# Altium schematic sheet IMX7S_WIRELESS.SchDoc
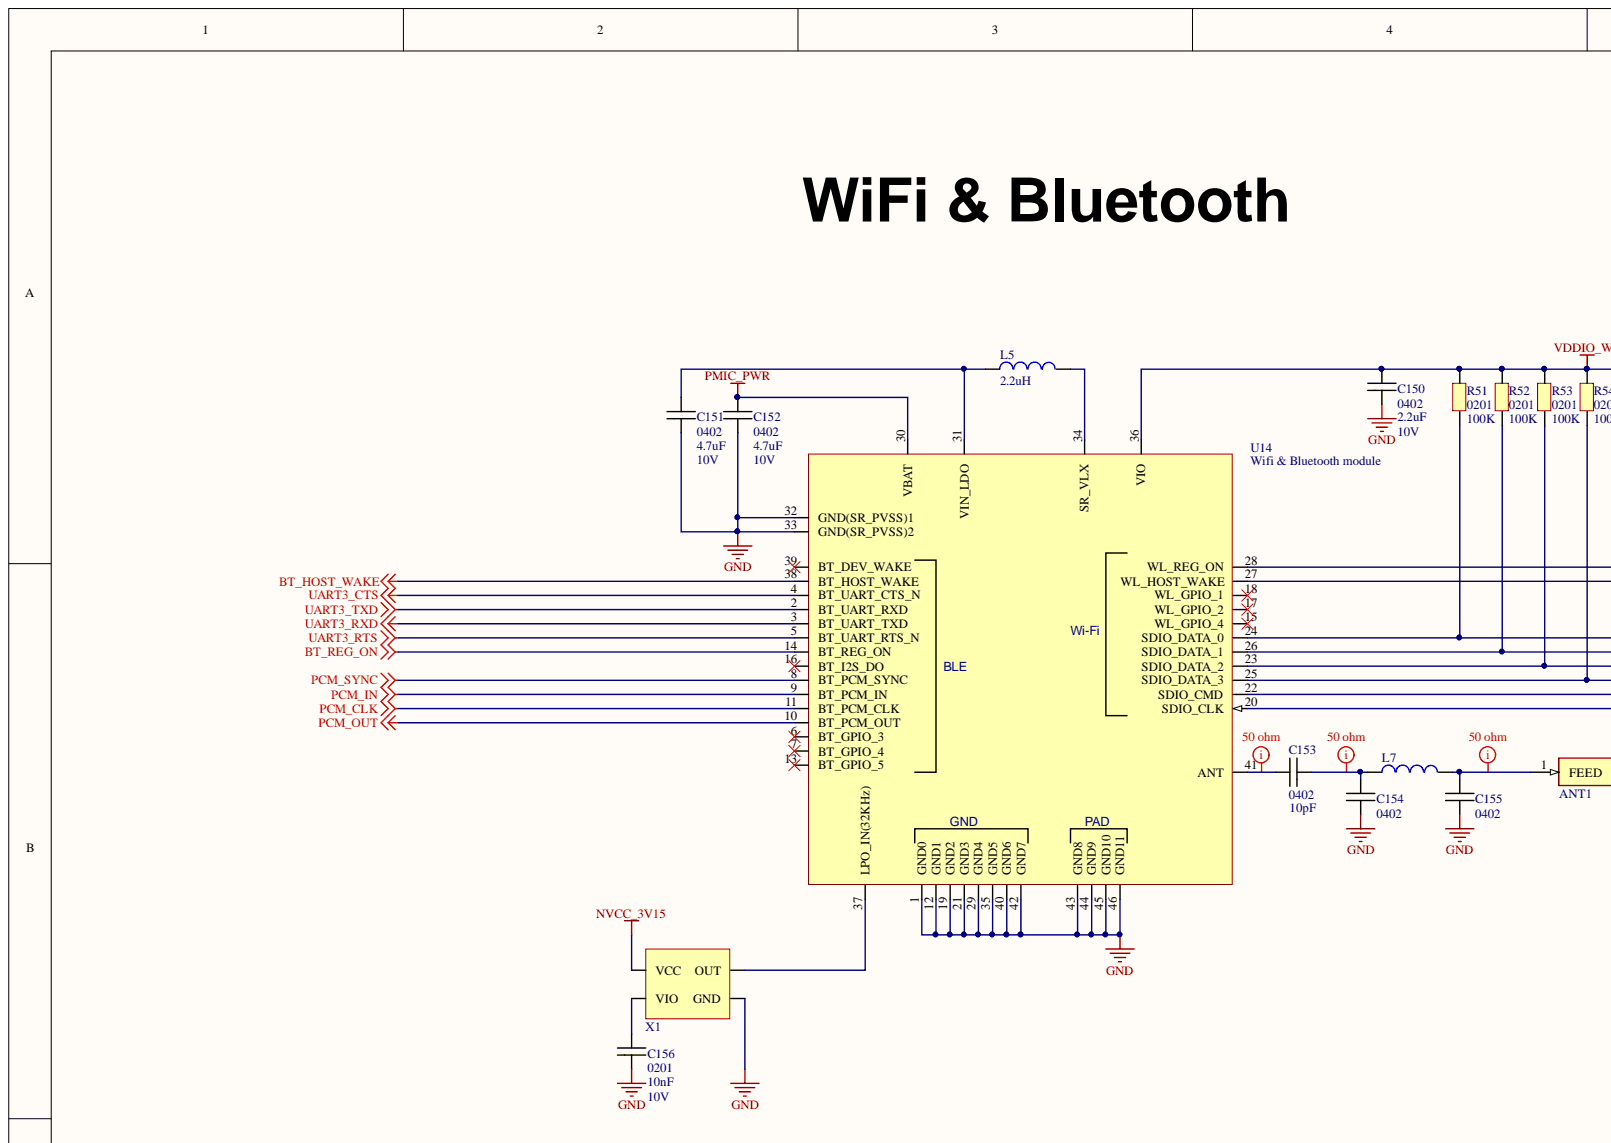
[diagram p0: IMX7S_WIRELESS.SchDoc, top left]
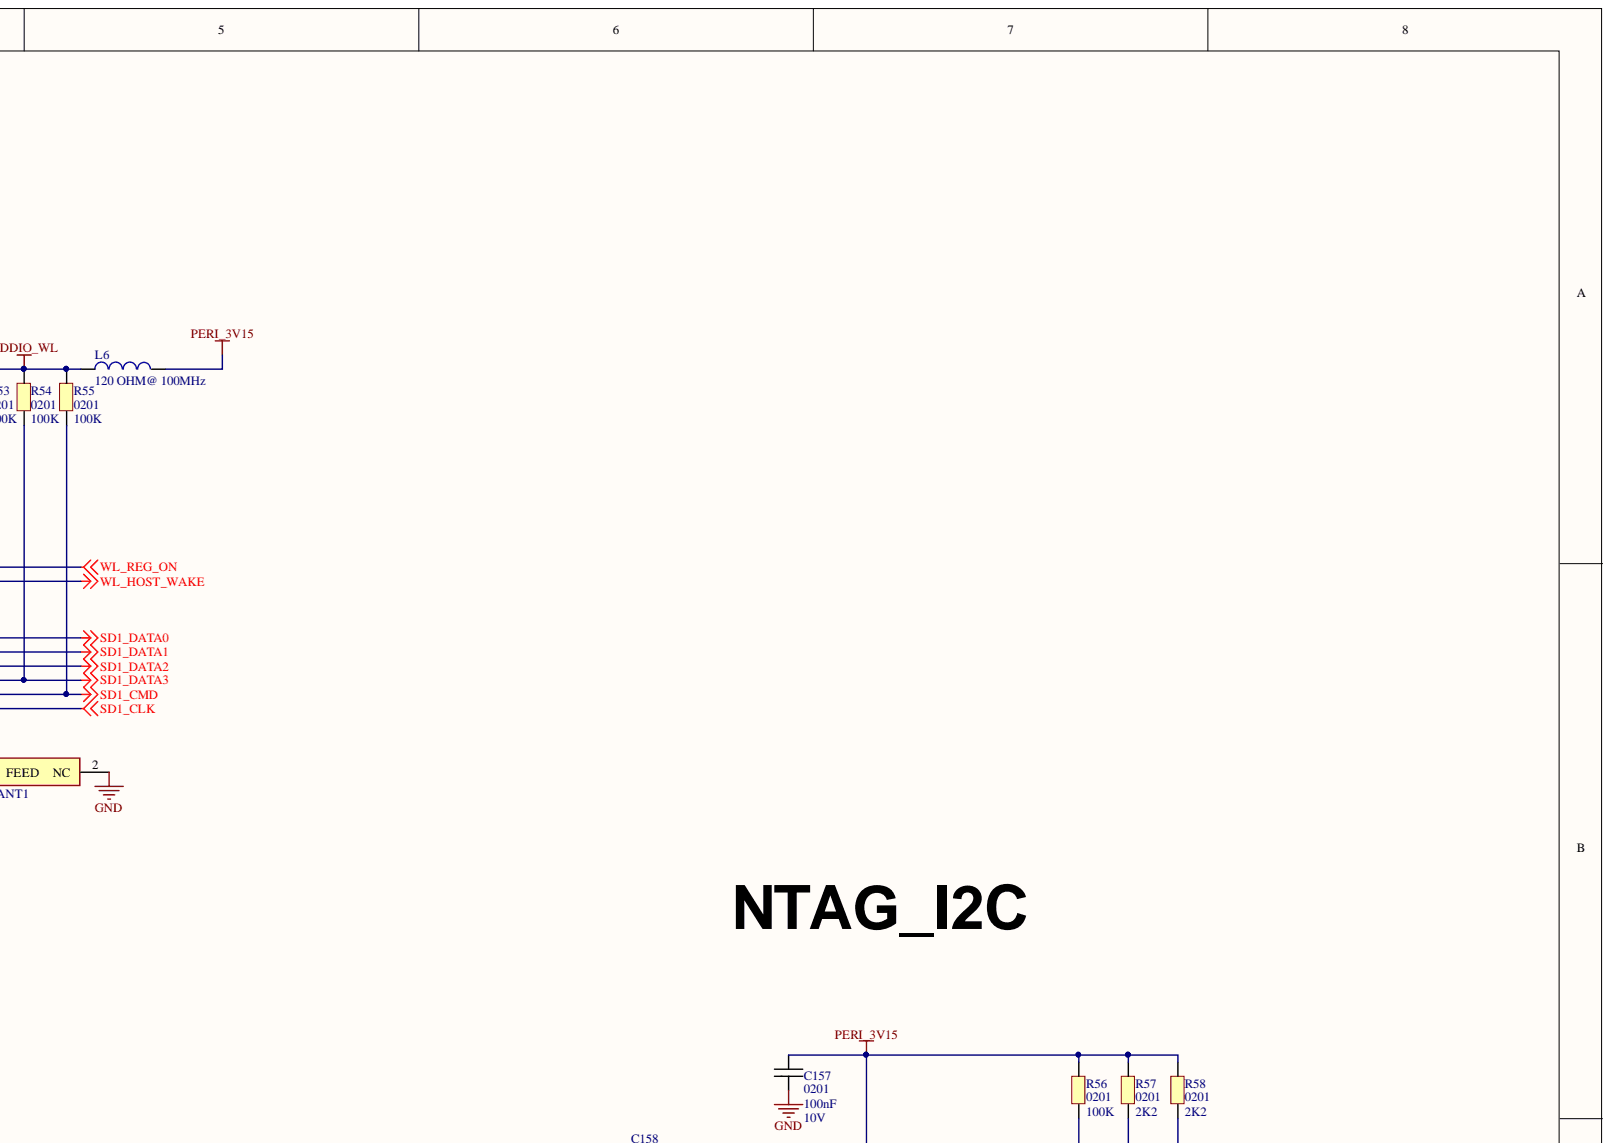
[diagram p1: IMX7S_WIRELESS.SchDoc, top right]
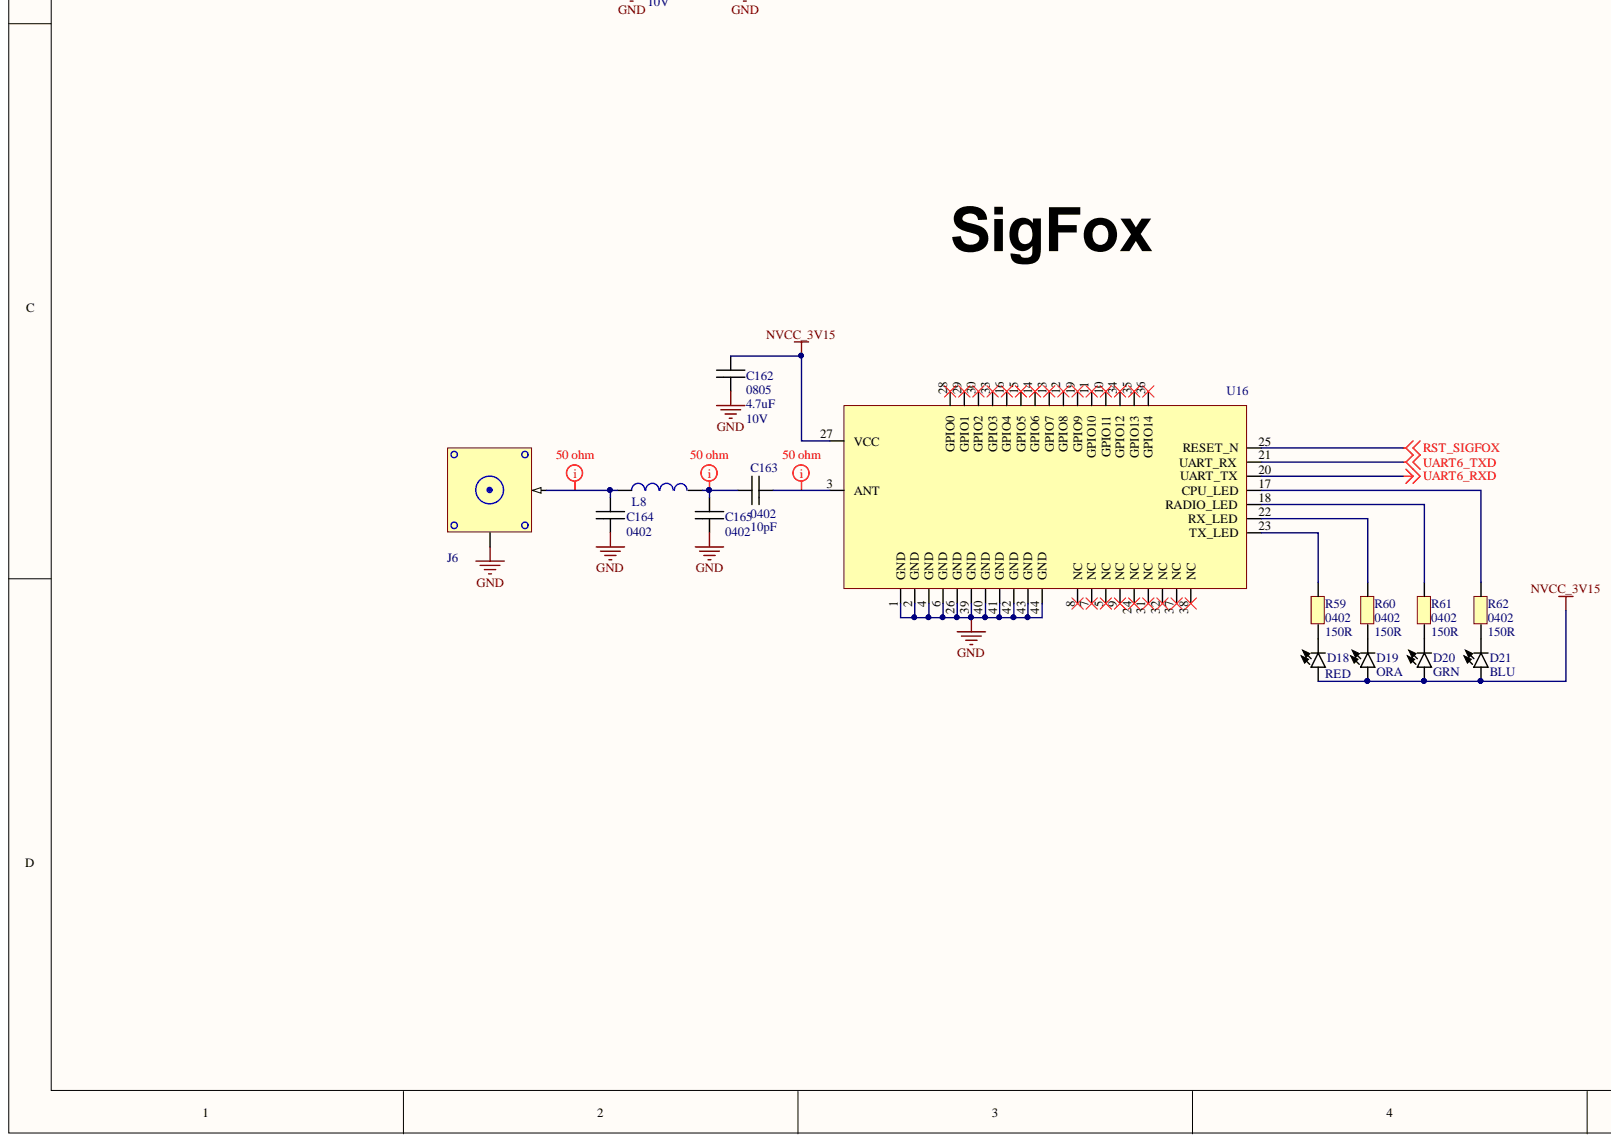
[diagram p2: IMX7S_WIRELESS.SchDoc, bottom left]
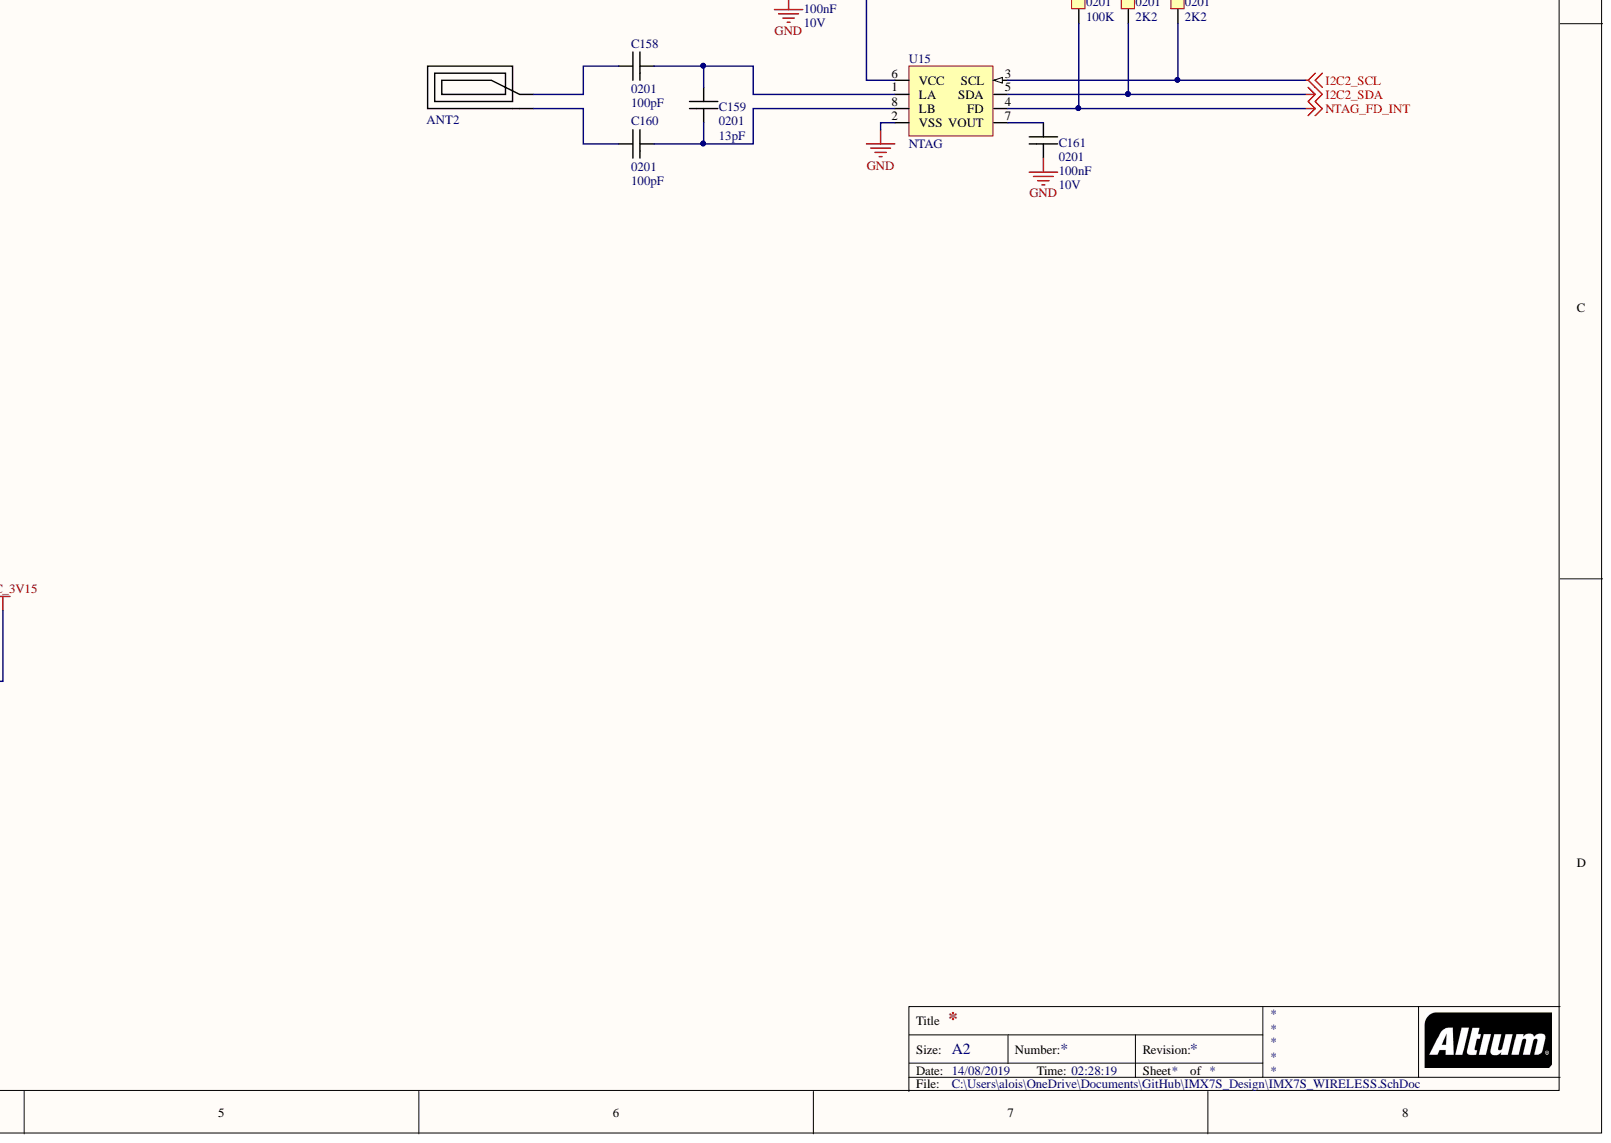
[diagram p3: IMX7S_WIRELESS.SchDoc, bottom right]

## Parts
ANT1  SRCW004  [SRCW004]  (value not drawn)
ANT2  NFC_ANT  [NFC Antenna]  (value not drawn)
C150  value 2.2uF  PACKAGE: 0402  VOLTAGE: 10V  [C0402]  (hidden comment: C0402)
C151  value 4.7uF  PACKAGE: 0402  VOLTAGE: 10V  [C0402]  (hidden comment: C0402)
C152  value 4.7uF  PACKAGE: 0402  VOLTAGE: 10V  [C0402]  (hidden comment: C0402)
C153  value 10pF  PACKAGE: 0402  [C0402]  (hidden comment: C0402)
C154  PACKAGE: 0402  [C0402]  (hidden comment: C0402)
C155  PACKAGE: 0402  [C0402]  (hidden comment: C0402)
C156  value 10nF  PACKAGE: 0201  VOLTAGE: 10V  [C0201]  (hidden comment: C0201)
C157  value 100nF  PACKAGE: 0201  VOLTAGE: 10V  [C0201]  (hidden comment: C0201)
C158  value 100pF  PACKAGE: 0201  [C0201]  (hidden comment: C0201)
C159  value 13pF  PACKAGE: 0201  [C0201]  (hidden comment: C0201)
C160  value 100pF  PACKAGE: 0201  [C0201]  (hidden comment: C0201)
C161  value 100nF  PACKAGE: 0201  VOLTAGE: 10V  [C0201]  (hidden comment: C0201)
C162  value 4.7uF  PACKAGE: 0805  VOLTAGE: 10V  [C0805]  (hidden comment: C0805)
C163  value 10pF  PACKAGE: 0402  [C0402]  (hidden comment: C0402)
C164  PACKAGE: 0402  [C0402]  (hidden comment: C0402)
C165  PACKAGE: 0402  [C0402]  (hidden comment: C0402)
D18  RED  [D0603]
D19  ORA  [D0603]
D20  GRN  [D0603]
D21  BLU  [D0603]
J6  SMA  [SMA]  (value not drawn)
L5  value 2.2uH  [R0402]  (hidden comment: Self)
L6  value 120 OHM@ 100MHz  [SELF]  (hidden comment: Self)
L7  Self  [R0402]  (value not drawn)
L8  Self  [R0402]  (value not drawn)
R51  value 100K  PACKAGE: 0201  [R0201]  (hidden comment: R0201)
R52  value 100K  PACKAGE: 0201  [R0201]  (hidden comment: R0201)
R53  value 100K  PACKAGE: 0201  [R0201]  (hidden comment: R0201)
R54  value 100K  PACKAGE: 0201  [R0201]  (hidden comment: R0201)
R55  value 100K  PACKAGE: 0201  [R0201]  (hidden comment: R0201)
R56  value 100K  PACKAGE: 0201  [R0201]  (hidden comment: R0201)
R57  value 2K2  PACKAGE: 0201  [R0201]  (hidden comment: R0201)
R58  value 2K2  PACKAGE: 0201  [R0201]  (hidden comment: R0201)
R59  R0402  value 150R  PACKAGE: 0402  [R0402]
R60  R0402  value 150R  PACKAGE: 0402  [R0402]
R61  R0402  value 150R  PACKAGE: 0402  [R0402]
R62  R0402  value 150R  PACKAGE: 0402  [R0402]
U14  Wifi & Bluetooth module  [LBEE5KL1DX]
U15  NTAG  [TSSOP8]
U16  AX-SIP-SFEU-1-01-TX30  [AX-SIP-SFEU-1-01-TX30]  (value not drawn)
X1  X1B0002110001 SG-3030CM 32.768KHZ B  [SPXO]  (value not drawn)

## Nets
BT_HOST_WAKE: U14.38(BT_HOST_WAKE)
BT_REG_ON: U14.14(BT_REG_ON)
GND: ANT1.2 C150.1 C151.1 C152.1 C154.1 C155.1 C156.1 C157.1 C161.1 C162.1 C164.1 C165.1 J6.2 U14.1(GND0) U14.12(GND1) U14.19(GND2) U14.21(GND3) U14.29(GND4) U14.32(GND(SR_PVSS)1) U14.33(GND(SR_PVSS)2) U14.35(GND5) U14.40(GND6) U14.42(GND7) U14.43(GND8) U14.44(GND9) U14.45(GND10) U14.46(GND11) U15.2(VSS) U16.1(GND) U16.2(GND) U16.4(GND) U16.6(GND) U16.26(GND) U16.39(GND) U16.40(GND) U16.41(GND) U16.42(GND) U16.43(GND) U16.44(GND) X1.2(GND)
I2C2_SCL: R58.1 U15.3(SCL)
I2C2_SDA: R57.1 U15.5(SDA)
NTAG_FD_INT: R56.1 U15.4(FD)
NVCC_3V15: C162.2 D18.1 D19.1 D20.1 D21.1 U16.27(VCC) X1.4(VCC)
PCM_CLK: U14.11(BT_PCM_CLK)
PCM_IN: U14.9(BT_PCM_IN)
PCM_OUT: U14.10(BT_PCM_OUT)
PCM_SYNC: U14.8(BT_PCM_SYNC)
PERI_3V15: C157.2 L6.2 R56.2 R57.2 R58.2 U15.6(VCC)
PMIC_PWR: C152.2 U14.30(VBAT)
RST_SIGFOX: U16.25(RESET_N)
SD1_CLK: U14.20(SDIO_CLK)
SD1_CMD: R55.1 U14.22(SDIO_CMD)
SD1_DATA0: R51.1 U14.24(SDIO_DATA_0)
SD1_DATA1: R52.1 U14.26(SDIO_DATA_1)
SD1_DATA2: R53.1 U14.23(SDIO_DATA_2)
SD1_DATA3: R54.1 U14.25(SDIO_DATA_3)
UART3_CTS: U14.4(BT_UART_CTS_N)
UART3_RTS: U14.5(BT_UART_RTS_N)
UART3_RXD: U14.3(BT_UART_TXD)
UART3_TXD: U14.2(BT_UART_RXD)
UART6_RXD: U16.20(UART_TX)
UART6_TXD: U16.21(UART_RX)
VDDIO_WL: C150.2 L6.1 R51.2 R52.2 R53.2 R54.2 R55.2 U14.36(VIO)
WL_HOST_WAKE: U14.27(WL_HOST_WAKE)
WL_REG_ON: U14.28(WL_REG_ON)
NetANT1_1: ANT1.1 C155.2 L7.2
NetANT2_1: ANT2.1 C158.2
NetANT2_2: ANT2.2 C160.2
NetC151_2: C151.2 L5.1 U14.31(VIN_LDO)
NetC153_1: C153.1 C154.2 L7.1
NetC153_2: C153.2 U14.41(ANT)
NetC156_2: C156.2 X1.1(VIO)
NetC158_1: C158.1 C159.2 U15.1(LA)
NetC159_1: C159.1 C160.1 U15.8(LB)
NetC161_2: C161.2 U15.7(VOUT)
NetC163_1: C163.1 U16.3(ANT)
NetC163_2: C163.2 C165.2 L8.2
NetC164_2: C164.2 J6.1 L8.1
NetD18_2: D18.2 R59.1
NetD19_2: D19.2 R60.1
NetD20_2: D20.2 R61.1
NetD21_2: D21.2 R62.1
NetL5_2: L5.2 U14.34(SR_VLX)
NetR59_2: R59.2 U16.23(TX_LED)
NetR60_2: R60.2 U16.22(RX_LED)
NetR61_2: R61.2 U16.18(RADIO_LED)
NetR62_2: R62.2 U16.17(CPU_LED)
NetU14_37: U14.37(LPO_IN(32KHz)) X1.3(OUT)

Not connected: U14 6(BT_GPIO_3) 7(BT_GPIO_4) 13(BT_GPIO_5) 15(WL_GPIO_4) 16(BT_I2S_DO) 17(WL_GPIO_2) 18(WL_GPIO_1) 39(BT_DEV_WAKE); U16 5(NC) 7(NC) 8(NC) 9(NC) 10(GPIO11) 11(GPIO10) 12(GPIO8) 13(GPIO7) 14(GPIO6) 15(GPIO5) 16(GPIO4) 19(GPIO9) 24(NC) 28(GPIO0) 29(GPIO1) 30(GPIO2) 31(NC) 32(NC) 33(GPIO3) 34(GPIO12) 35(GPIO13) 36(GPIO14) 37(NC) 38(NC)

## Library data of the parts
ANT1 = SRCW004: Antenna; Manufacturer_Name: Antenova; Component Kind: Standard; Datasheet Link: https://componentsearchengine.com/Datasheets/1/SRCW004.pdf; Library Name: Schlib_IMX7.SchLib; Manufacturer_Part_Number: SRCW004; Mouser Part Number: 237-SRCW004; Mouser Price/Stock: https://www.mouser.com/Search/Refine.aspx?Keyword=237-SRCW004
C153 = C0402: VOLTAGE: 0V; Component Kind: Standard; Library Name: Schlib_IMX7.SchLib
C154 C155 C164 C165 = C0402: VALUE: 0nF; VOLTAGE: 0V; Component Kind: Standard; Library Name: Schlib_IMX7.SchLib
C158 = C0201: VALUE: 100pF; VOLTAGE: 10V; Component Kind: Standard; Library Name: Schlib_IMX7.SchLib
C159 C160 = C0201: VOLTAGE: 10V; Component Kind: Standard; Library Name: Schlib_IMX7.SchLib
C163 = C0402: VALUE: 10pF; VOLTAGE: 0V; Component Kind: Standard; Library Name: Schlib_IMX7.SchLib
D18-D21 = LED: Component Kind: Standard; Library Name: Schlib_IMX7.SchLib
L7 L8 = Self: Value: 0uH; Component Kind: Standard; Library Name: Schlib_IMX7.SchLib
R51-R58 = R0201: VOLTAGE: 0V; Component Kind: Standard; Library Name: Schlib_IMX7.SchLib
R59-R62 = R0402: VOLTAGE: 0V; Component Kind: Standard; Library Name: Schlib_IMX7.SchLib
U14 = LBEE5KL1X-833: Component Kind: Standard; Library Name: Schlib_IMX7.SchLib; Supplier 1: Mouser; Supplier Part Number 1: 81-LBEE5KL1DX-883
U15 = NT3H110W0FHK: Component Kind: Standard; Library Name: Schlib_IMX7.SchLib
X1 = Oscillateur: Component Kind: Standard; Library Name: Schlib_IMX7.SchLib
ANT2 = NFC_ANT: Component Kind: Standard; Library Name: Schlib_IMX7.SchLib
C150-C152 = C0402: Component Kind: Standard; Library Name: Schlib_IMX7.SchLib
C156 C157 C161 = C0201: Component Kind: Standard; Library Name: Schlib_IMX7.SchLib
C162 = C0805: Component Kind: Standard; Library Name: Schlib_IMX7.SchLib
J6 = SMA: Component Kind: Standard; Library Name: Schlib_IMX7.SchLib
L5 L6 = Self: Component Kind: Standard; Library Name: Schlib_IMX7.SchLib
U16 = AX-SIP-SFEU-1-01-TX30: Component Kind: Standard; Library Name: Schlib_IMX7.SchLib
